# Altium schematic sheet 7_segment.SchDoc(7_segment)
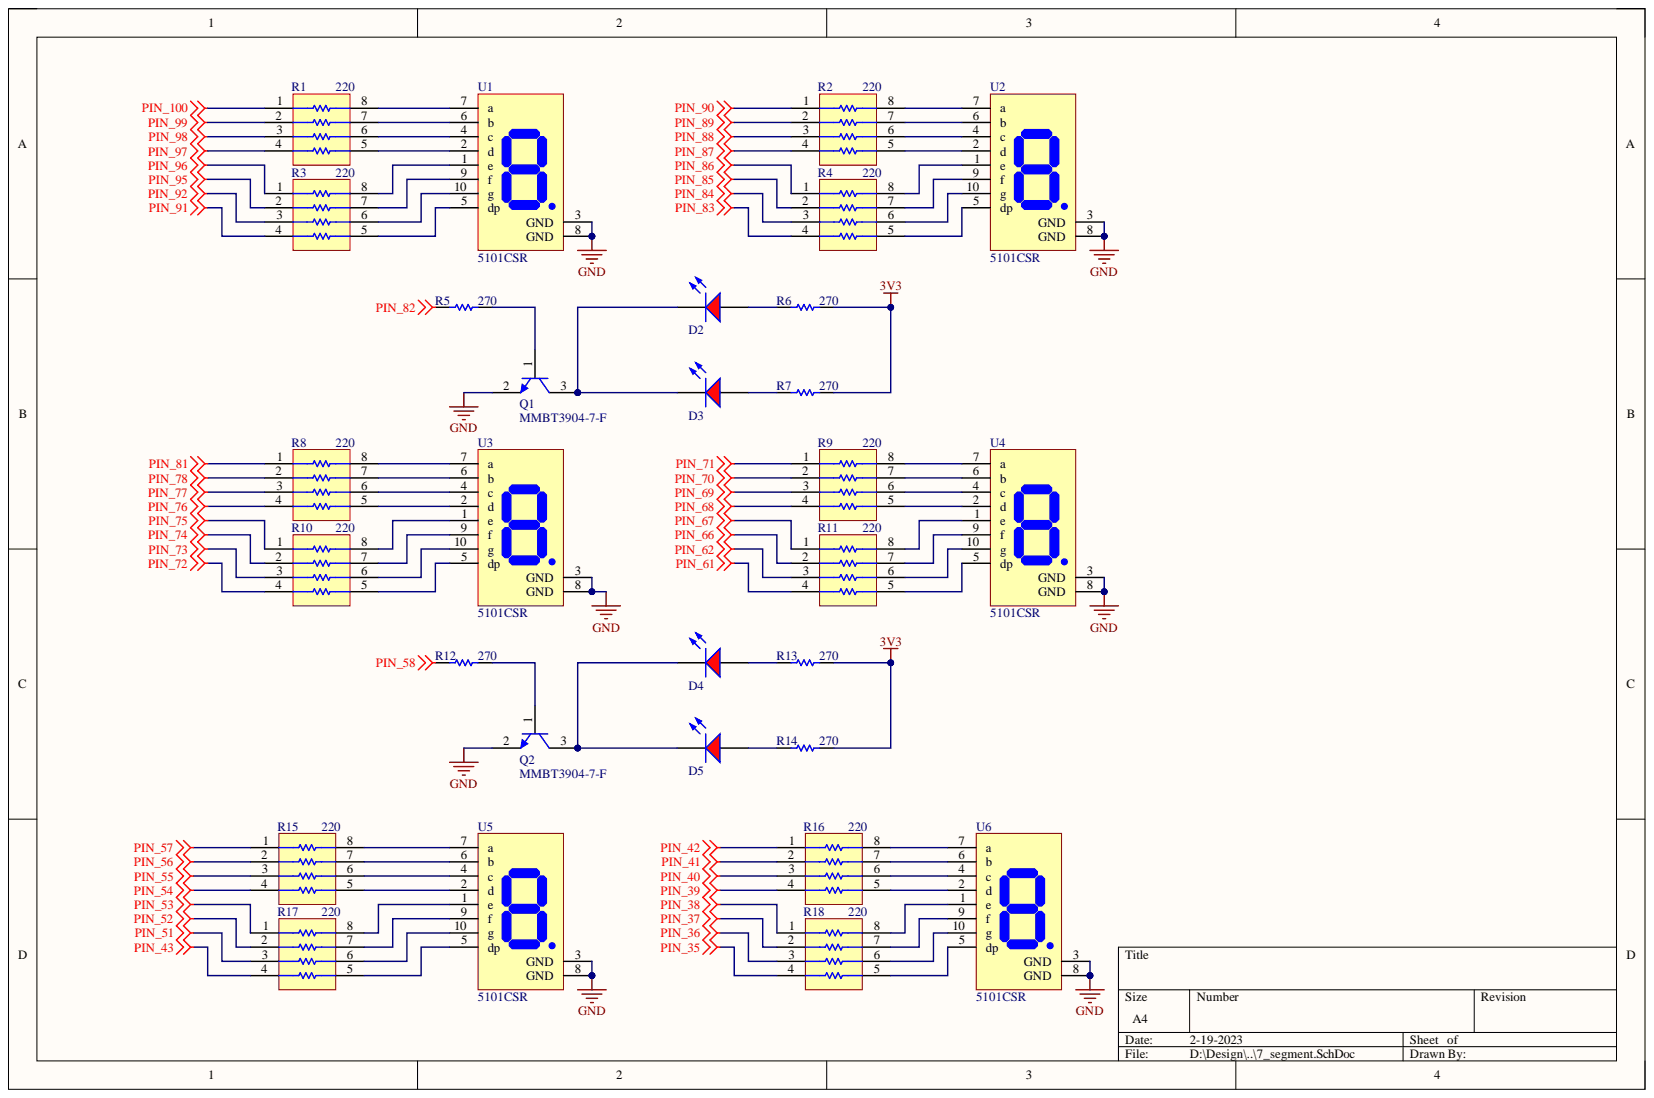
[diagram p0: 7_segment.SchDoc]

## Parts
D2  5mm Red LED  [5mm Red LED-Footprint-1]  (value not drawn)
D3  5mm Red LED  [5mm Red LED-Footprint-1]  (value not drawn)
D4  5mm Red LED  [5mm Red LED-Footprint-1]  (value not drawn)
D5  5mm Red LED  [5mm Red LED-Footprint-1]  (value not drawn)
Q1  MMBT3904-7-F  [DIOD-SOT23-3_V]
Q2  MMBT3904-7-F  [DIOD-SOT23-3_V]
R1  value 220  [CAC-50P200X100-8N]  (hidden comment: Resistor/2012 Array)
R2  value 220  [CAC-50P200X100-8N]  (hidden comment: Resistor/2012 Array)
R3  value 220  [CAC-50P200X100-8N]  (hidden comment: Resistor/2012 Array)
R4  value 220  [CAC-50P200X100-8N]  (hidden comment: Resistor/2012 Array)
R5  value 270  [Resistor 1608-Footprint]  (hidden comment: Resistor/1608)
R6  value 270  [Resistor 1608-Footprint]  (hidden comment: Resistor/1608)
R7  value 270  [Resistor 1608-Footprint]  (hidden comment: Resistor/1608)
R8  value 220  [CAC-50P200X100-8N]  (hidden comment: Resistor/2012 Array)
R9  value 220  [CAC-50P200X100-8N]  (hidden comment: Resistor/2012 Array)
R10  value 220  [CAC-50P200X100-8N]  (hidden comment: Resistor/2012 Array)
R11  value 220  [CAC-50P200X100-8N]  (hidden comment: Resistor/2012 Array)
R12  value 270  [Resistor 1608-Footprint]  (hidden comment: Resistor/1608)
R13  value 270  [Resistor 1608-Footprint]  (hidden comment: Resistor/1608)
R14  value 270  [Resistor 1608-Footprint]  (hidden comment: Resistor/1608)
R15  value 220  [CAC-50P200X100-8N]  (hidden comment: Resistor/2012 Array)
R16  value 220  [CAC-50P200X100-8N]  (hidden comment: Resistor/2012 Array)
R17  value 220  [CAC-50P200X100-8N]  (hidden comment: Resistor/2012 Array)
R18  value 220  [CAC-50P200X100-8N]  (hidden comment: Resistor/2012 Array)
U1  5101CSR  [19mm_1DIG]
U2  5101CSR  [19mm_1DIG]
U3  5101CSR  [19mm_1DIG]
U4  5101CSR  [19mm_1DIG]
U5  5101CSR  [19mm_1DIG]
U6  5101CSR  [19mm_1DIG]

## Nets
3V3: R6.2 R7.2 R13.2 R14.2
GND: Q1.2 Q2.2 U1.3(GND) U1.8(GND) U2.3(GND) U2.8(GND) U3.3(GND) U3.8(GND) U4.3(GND) U4.8(GND) U5.3(GND) U5.8(GND) U6.3(GND) U6.8(GND)
PIN_35: R18.4
PIN_36: R18.3
PIN_37: R18.2
PIN_38: R18.1
PIN_39: R16.4
PIN_40: R16.3
PIN_41: R16.2
PIN_42: R16.1
PIN_43: R17.4
PIN_51: R17.3
PIN_52: R17.2
PIN_53: R17.1
PIN_54: R15.4
PIN_55: R15.3
PIN_56: R15.2
PIN_57: R15.1
PIN_58: R12.1
PIN_61: R11.4
PIN_62: R11.3
PIN_66: R11.2
PIN_67: R11.1
PIN_68: R9.4
PIN_69: R9.3
PIN_70: R9.2
PIN_71: R9.1
PIN_72: R10.4
PIN_73: R10.3
PIN_74: R10.2
PIN_75: R10.1
PIN_76: R8.4
PIN_77: R8.3
PIN_78: R8.2
PIN_81: R8.1
PIN_82: R5.1
PIN_83: R4.4
PIN_84: R4.3
PIN_85: R4.2
PIN_86: R4.1
PIN_87: R2.4
PIN_88: R2.3
PIN_89: R2.2
PIN_90: R2.1
PIN_91: R3.4
PIN_92: R3.3
PIN_95: R3.2
PIN_96: R3.1
PIN_97: R1.4
PIN_98: R1.3
PIN_99: R1.2
PIN_100: R1.1
NetD2_1: D2.1 R6.1
NetD2_2: D2.2 D3.2 Q1.3
NetD3_1: D3.1 R7.1
NetD4_1: D4.1 R13.1
NetD4_2: D4.2 D5.2 Q2.3
NetD5_1: D5.1 R14.1
NetQ1_1: Q1.1 R5.2
NetQ2_1: Q2.1 R12.2
NetR1_5: R1.5 U1.2(d)
NetR1_6: R1.6 U1.4(c)
NetR1_7: R1.7 U1.6(b)
NetR1_8: R1.8 U1.7(a)
NetR2_5: R2.5 U2.2(d)
NetR2_6: R2.6 U2.4(c)
NetR2_7: R2.7 U2.6(b)
NetR2_8: R2.8 U2.7(a)
NetR3_5: R3.5 U1.5(dp)
NetR3_6: R3.6 U1.10(g)
NetR3_7: R3.7 U1.9(f)
NetR3_8: R3.8 U1.1(e)
NetR4_5: R4.5 U2.5(dp)
NetR4_6: R4.6 U2.10(g)
NetR4_7: R4.7 U2.9(f)
NetR4_8: R4.8 U2.1(e)
NetR8_5: R8.5 U3.2(d)
NetR8_6: R8.6 U3.4(c)
NetR8_7: R8.7 U3.6(b)
NetR8_8: R8.8 U3.7(a)
NetR9_5: R9.5 U4.2(d)
NetR9_6: R9.6 U4.4(c)
NetR9_7: R9.7 U4.6(b)
NetR9_8: R9.8 U4.7(a)
NetR10_5: R10.5 U3.5(dp)
NetR10_6: R10.6 U3.10(g)
NetR10_7: R10.7 U3.9(f)
NetR10_8: R10.8 U3.1(e)
NetR11_5: R11.5 U4.5(dp)
NetR11_6: R11.6 U4.10(g)
NetR11_7: R11.7 U4.9(f)
NetR11_8: R11.8 U4.1(e)
NetR15_5: R15.5 U5.2(d)
NetR15_6: R15.6 U5.4(c)
NetR15_7: R15.7 U5.6(b)
NetR15_8: R15.8 U5.7(a)
NetR16_5: R16.5 U6.2(d)
NetR16_6: R16.6 U6.4(c)
NetR16_7: R16.7 U6.6(b)
NetR16_8: R16.8 U6.7(a)
NetR17_5: R17.5 U5.5(dp)
NetR17_6: R17.6 U5.10(g)
NetR17_7: R17.7 U5.9(f)
NetR17_8: R17.8 U5.1(e)
NetR18_5: R18.5 U6.5(dp)
NetR18_6: R18.6 U6.10(g)
NetR18_7: R18.7 U6.9(f)
NetR18_8: R18.8 U6.1(e)

## Library data of the parts
D2-D5 = CMP-008-0009-2: Generic 5mm LED <RED>; Component Kind: Standard; Library Name: C6H6`s Vault
Q1 Q2 = CMP-014-0028-1: Trans GP BJT NPN 40V 0.2A Automotive 3-Pin SOT-23 T/R; Collector Base Voltage (VCBO): 60V; Collector Emitter Saturation Voltage: 300mV; Continuous Collector Current: 200mA; Element Configuration: Single; Emitter Base Voltage (VEBO): 6V; Frequency: 300MHz; hFE Min: 100; Max Power Dissipation: 300mW; Number of Elements: 1; Power Dissipation: 350mW; Case/Package: SOT-23; Collector Emitter Breakdown Voltage: 40V; Collector Emitter Voltage (VCEO): 40V; Component Kind: Standard; Contact Plating: Tin,Matte; Gain Bandwidth Product: 300MHz; Height: 1mm; Lead Free: Lead Free; Length: 3.05mm; Library Name: C6H6`s Vault; Max Breakdown Voltage: 40V; Max Collector Current: 200mA; Max Frequency: 300MHz; Max Operating Temperature: 150°C; Min Operating Temperature: -55°C; Mount: Surface Mount; Number of Pins: 3; Package Quantity: 3000; Packaging: Tape and Reel; Polarity: NPN; Radiation Hardening: No; REACH SVHC: No SVHC; Transition Frequency: 300MHz; Voltage Rating (DC): 40V; Weight: 0.000282oz; Width: 1.4mm
R1-R4 R8-R11 R15-R18 = CMP-001-0028-2: Generic 2012 Array Resistor; Case/Package: 2012 Array; Component Kind: Standard; Library Name: C6H6`s Vault; Mount: SMD; RoHS: Yes
R5-R7 R12-R14 = CMP-001-0018-2: Generic 1608 Resistor; Case/Package: Bulk; Component Kind: Standard; Library Name: C6H6`s Vault; Mount: SMD; Pins: 2; RoHS: Yes
U1-U6 = CMP-00290-1: 7-Segment Common Cathode, Red, 0.56 inch (12x19mm); Component Kind: Standard; Component Name: 5101CSR; Designator: 5101CSR; Library Name: C6H6`s Vault; Pin Count: 10
